# Altium schematic sheet ETH&AUDIO&SDRAM.SchDoc(ETH&AUDIO&SDRAM)
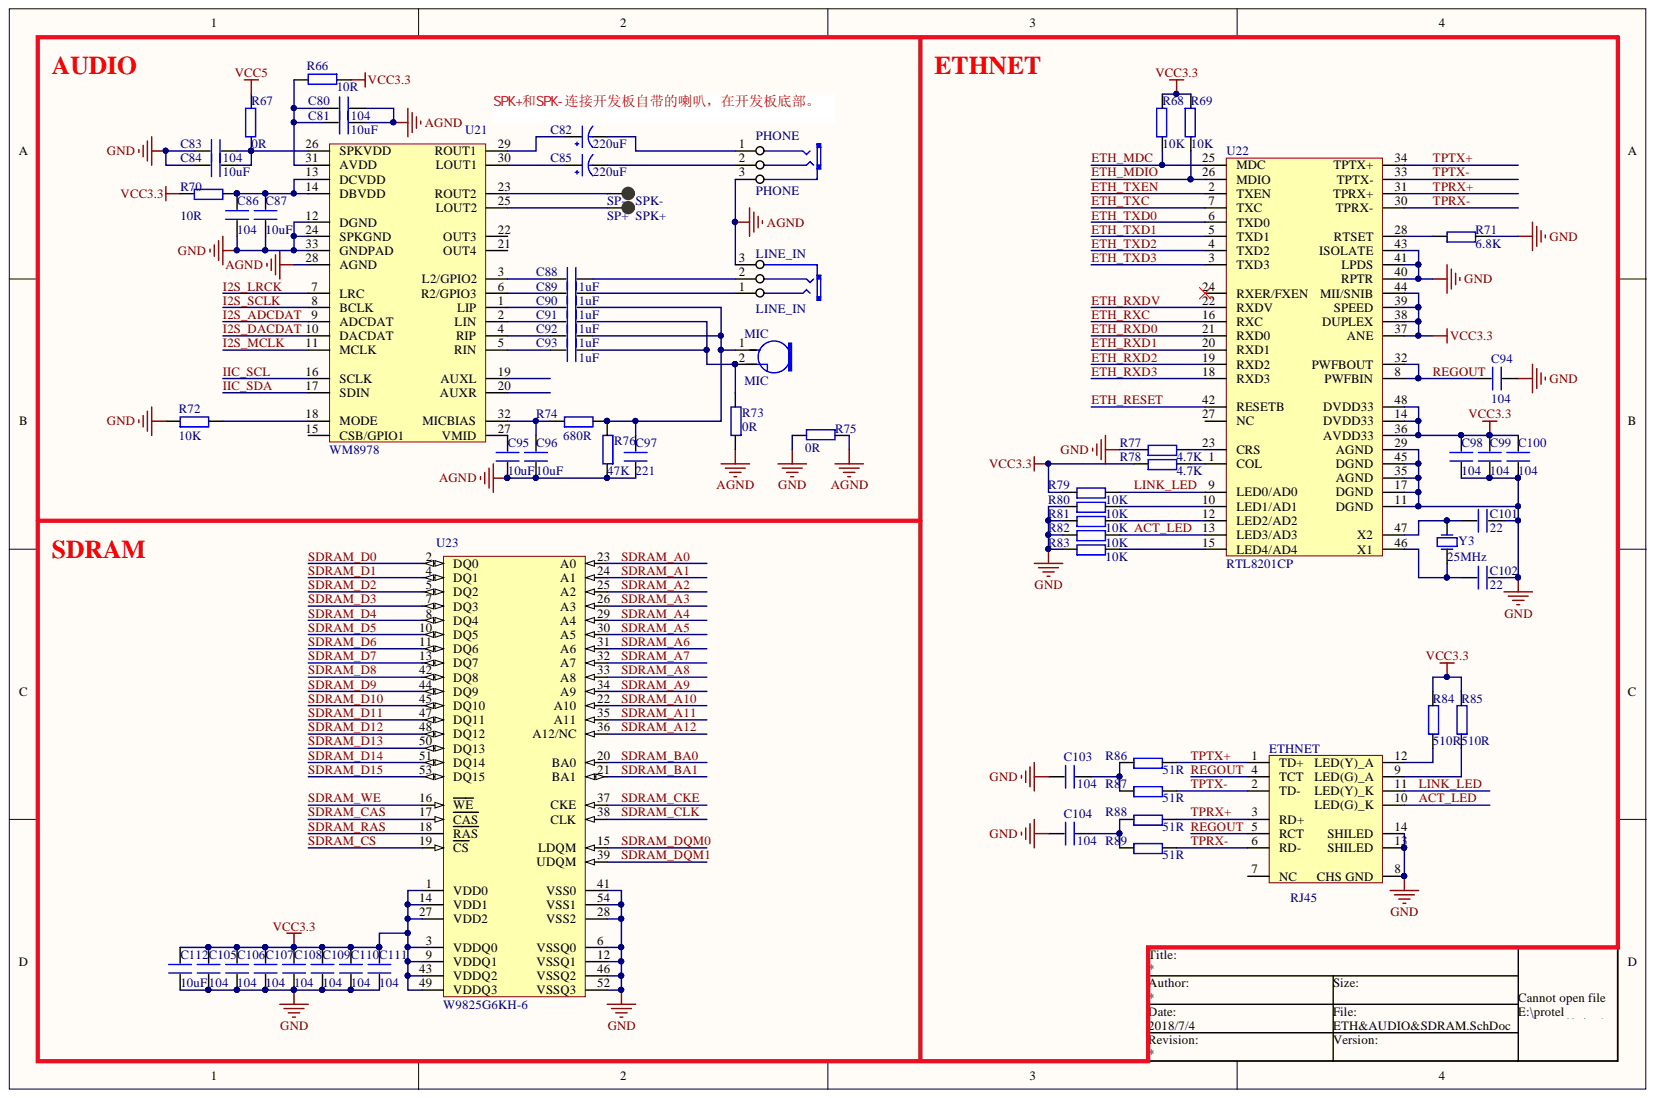
[diagram p0: ETH&AUDIO&SDRAM.SchDoc]

## Parts
C80  104  [0603P]
C81  10uF  [0805]
C82  220uF  [CC6.56.5]
C83  104  [0603P]
C84  10uF  [0805]
C85  220uF  [CC6.56.5]
C86  104  [0603P]
C87  10uF  [0805]
C88  1uF  [0603P]
C89  1uF  [0603P]
C90  1uF  [0603P]
C91  1uF  [0603P]
C92  1uF  [0603P]
C93  1uF  [0603P]
C94  104  [0603P]
C95  10uF  [0805]
C96  10uF  [0805]
C97  221  [0603P]
C98  104  [0603P]
C99  104  [0603P]
C100  104  [0603P]
C101  22  [0603P]
C102  22  [0603P]
C103  104  [0603P]
C104  104  [0603P]
C105  104  [0603P]
C106  104  [0603P]
C107  104  [0603P]
C108  104  [0603P]
C109  104  [0603P]
C110  104  [0603P]
C111  104  [0603P]
C112  10uF  [0805]
ETHNET  RJ45  [HR911105A]
LINE_IN  LINE_IN  [PHONE_ST]
MIC  MIC  [MIC-6022]
PHONE  PHONE  [PHONE_ST]
R66  10R  [0603P]
R67  0R  [0603P]
R68  10K  [0603P]
R69  10K  [0603P]
R70  10R  [0603P]
R71  6.8K  [0603P]
R72  10K  [0603P]
R73  0R  [0603P]
R74  680R  [0603P]
R75  0R  [0603P]
R76  47K  [0603P]
R77  4.7K  [0603P]
R78  4.7K  [0603P]
R79  10K  [0603P]
R80  10K  [0603P]
R81  10K  [0603P]
R82  10K  [0603P]
R83  10K  [0603P]
R84  510R  [0603P]
R85  510R  [0603P]
R86  51R  [0603P]
R87  51R  [0603P]
R88  51R  [0603P]
R89  51R  [0603P]
SP+  SPK+  [TP-1.5]
SP-  SPK-  [TP-1.5]
U21  WM8978  [qfn32]
U22  RTL8201CP  [lqfp48s]
U23  W9825G6KH-6  [TSOP54]
Y3  25MHz  [XTAL_US]

## Nets
AGND: C80.2 C81.2 C95.2 C96.1 C97.1 LINE_IN.3 PHONE.3 R73.1 R75.1 R76.1 U21.28(AGND)
GND: C83.2 C84.2 C86.2 C87.2 C94.1 C98.2 C99.2 C100.2 C101.2 C102.2 C103.2 C104.2 C105.1 C106.1 C107.1 C108.1 C109.1 C110.1 C111.1 C112.2 ETHNET.8(CHS_GND) ETHNET.13(SHILED) ETHNET.14(SHILED) R71.2 R72.1 R75.2 R77.1 R80.1 R81.1 R82.1 R83.1 U21.12(DGND) U21.24(SPKGND) U21.33(GNDPAD) U22.11(DGND) U22.17(DGND) U22.29(AGND) U22.35(AGND) U22.40(RPTR) U22.41(LPDS) U22.43(ISOLATE) U22.45(DGND) U23.6(VSSQ0) U23.12(VSSQ1) U23.28(VSS2) U23.41(VSS0) U23.46(VSSQ2) U23.52(VSSQ3) U23.54(VSS1)
VCC3.3: C98.1 C99.1 C100.1 C105.2 C106.2 C107.2 C108.2 C109.2 C110.2 C111.2 C112.1 R66.1 R68.1 R69.1 R70.1 R78.1 R79.1 R84.2 R85.2 U22.14(DVDD33) U22.36(AVDD33) U22.37(ANE) U22.38(DUPLEX) U22.39(SPEED) U22.44(MII/SNIB) U22.48(DVDD33) U23.1(VDD0) U23.3(VDDQ0) U23.9(VDDQ1) U23.14(VDD1) U23.27(VDD2) U23.43(VDDQ2) U23.49(VDDQ3)
VCC5: R67.1
ACT_LED: ETHNET.10(LED(G)_K) R82.2 U22.13(LED3/AD3)
ETH_MDC: R68.2 U22.25(MDC)
ETH_MDIO: R69.2 U22.26(MDIO)
ETH_RESET: U22.42(RESETB)
ETH_RXC: U22.16(RXC)
ETH_RXD0: U22.21(RXD0)
ETH_RXD1: U22.20(RXD1)
ETH_RXD2: U22.19(RXD2)
ETH_RXD3: U22.18(RXD3)
ETH_RXDV: U22.22(RXDV)
ETH_TXC: U22.7(TXC)
ETH_TXD0: U22.6(TXD0)
ETH_TXD1: U22.5(TXD1)
ETH_TXD2: U22.4(TXD2)
ETH_TXD3: U22.3(TXD3)
ETH_TXEN: U22.2(TXEN)
I2S_ADCDAT: U21.9(ADCDAT)
I2S_DACDAT: U21.10(DACDAT)
I2S_LRCK: U21.7(LRC)
I2S_MCLK: U21.11(MCLK)
I2S_SCLK: U21.8(BCLK)
IIC_SCL: U21.16(SCLK)
IIC_SDA: U21.17(SDIN)
LINK_LED: ETHNET.11(LED(Y)_K) R79.2 U22.9(LED0/AD0)
REGOUT: C94.2 ETHNET.4(TCT) ETHNET.5(RCT) U22.8(PWFBIN) U22.32(PWFBOUT)
SDRAM_A0: U23.23(A0)
SDRAM_A1: U23.24(A1)
SDRAM_A2: U23.25(A2)
SDRAM_A3: U23.26(A3)
SDRAM_A4: U23.29(A4)
SDRAM_A5: U23.30(A5)
SDRAM_A6: U23.31(A6)
SDRAM_A7: U23.32(A7)
SDRAM_A8: U23.33(A8)
SDRAM_A9: U23.34(A9)
SDRAM_A10: U23.22(A10)
SDRAM_A11: U23.35(A11)
SDRAM_A12: U23.36(A12/NC)
SDRAM_BA0: U23.20(BA0)
SDRAM_BA1: U23.21(BA1)
SDRAM_CAS: U23.17(CAS)
SDRAM_CKE: U23.37(CKE)
SDRAM_CLK: U23.38(CLK)
SDRAM_CS: U23.19(CS)
SDRAM_D0: U23.2(DQ0)
SDRAM_D1: U23.4(DQ1)
SDRAM_D2: U23.5(DQ2)
SDRAM_D3: U23.7(DQ3)
SDRAM_D4: U23.8(DQ4)
SDRAM_D5: U23.10(DQ5)
SDRAM_D6: U23.11(DQ6)
SDRAM_D7: U23.13(DQ7)
SDRAM_D8: U23.42(DQ8)
SDRAM_D9: U23.44(DQ9)
SDRAM_D10: U23.45(DQ10)
SDRAM_D11: U23.47(DQ11)
SDRAM_D12: U23.48(DQ12)
SDRAM_D13: U23.50(DQ13)
SDRAM_D14: U23.51(DQ14)
SDRAM_D15: U23.53(DQ15)
SDRAM_DQM0: U23.15(LDQM)
SDRAM_DQM1: U23.39(UDQM)
SDRAM_RAS: U23.18(RAS)
SDRAM_WE: U23.16(WE)
TPRX+: ETHNET.3(RD+) R88.2 U22.31(TPRX+)
TPRX-: ETHNET.6(RD-) R89.2 U22.30(TPRX-)
TPTX+: ETHNET.1(TD+) R86.2 U22.34(TPTX+)
TPTX-: ETHNET.2(TD-) R87.2 U22.33(TPTX-)
NetC80_1: C80.1 C81.1 R66.2 U21.31(AVDD)
NetC82_1: C82.1 U21.29(ROUT1)
NetC82_2: C82.2 PHONE.1
NetC83_1: C83.1 C84.1 R67.2 U21.26(SPKVDD)
NetC85_1: C85.1 U21.30(LOUT1)
NetC85_2: C85.2 PHONE.2
NetC86_1: C86.1 C87.1 R70.2 U21.13(DCVDD) U21.14(DBVDD)
NetC88_1: C88.1 U21.3(L2/GPIO2)
NetC88_2: C88.2 LINE_IN.2
NetC89_1: C89.1 U21.6(R2/GPIO3)
NetC89_2: C89.2 LINE_IN.1
NetC90_1: C90.1 U21.1(LIP)
NetC90_2: C90.2 C92.2 C97.2 MIC.1 R74.2 R76.2
NetC91_1: C91.1 U21.2(LIN)
NetC91_2: C91.2 C93.2 MIC.2 R73.2
NetC92_1: C92.1 U21.4(RIP)
NetC93_1: C93.1 U21.5(RIN)
NetC95_1: C95.1 U21.27(VMID)
NetC96_2: C96.2 R74.1 U21.32(MICBIAS)
NetC101_1: C101.1 U22.47(X2) Y3.1
NetC102_1: C102.1 U22.46(X1) Y3.2
NetC103_1: C103.1 R86.1 R87.1
NetC104_1: C104.1 R88.1 R89.1
NetETHNET_9: ETHNET.9(LED(G)_A) R85.1
NetETHNET_12: ETHNET.12(LED(Y)_A) R84.1
NetR71_1: R71.1 U22.28(RTSET)
NetR72_2: R72.2 U21.18(MODE)
NetR77_2: R77.2 U22.23(CRS)
NetR78_2: R78.2 U22.1(COL)
NetR80_2: R80.2 U22.10(LED1/AD1)
NetR81_2: R81.2 U22.12(LED2/AD2)
NetR83_2: R83.2 U22.15(LED4/AD4)
NetSP+_1: SP+.1 U21.25(LOUT2)
NetSP-_1: SP-.1 U21.23(ROUT2)

Not connected: ETHNET 7(NC); U21 15(CSB/GPIO1) 19(AUXL) 20(AUXR) 21(OUT4) 22(OUT3); U22 24(RXER/FXEN) 27(NC)

## Library data of the parts
C80 C81 C83 C84 C86-C112 = C: Library Name: ALIENTEK_COMPONENT.IntLib; Component Kind: Standard
C82 C85 = CAP: Library Name: MYCOMLIB.IntLib; Component Kind: Standard
ETHNET = HR911105: Library Name: MYCOMLIB.IntLib; Component Kind: Standard
LINE_IN PHONE = PHONE_M: Library Name: ALIENTEK_COMPONENT.IntLib; Component Kind: Standard
R66-R89 = R: Library Name: MYCOMLIB.IntLib; Component Kind: Standard
SP+ SP- = TEST-POINT: 测试点; Library Name: ALIENTEK_COMPONENT.IntLib; Component Kind: Standard
U21 = WM8978: 24bit ADC&DAC; Library Name: ALIENTEK_COMPONENT.IntLib; Component Kind: Standard
U22 = RTL8201CP: ETH PHY; Library Name: ALIENTEK_COMPONENT.IntLib; Component Kind: Standard
U23 = IS42S16160B: 32MB SDRAM; Library Name: ALIENTEK_COMPONENT.IntLib; Component Kind: Standard
Y3 = XTAL: 普通晶振; Published: 8-Jun-2000; Library Name: ALIENTEK_COMPONENT.IntLib; LatestRevisionNote: Re-released for DXP Platform.; LatestRevisionDate: 17-Jul-2002; Component Kind: Standard; Publisher: Altium Limited
MIC = MIC: Library Name: ALIENTEK_COMPONENT.IntLib; Component Kind: Standard
